# Altium schematic sheet DCDC_12V.SchDoc(DCDC_12V)
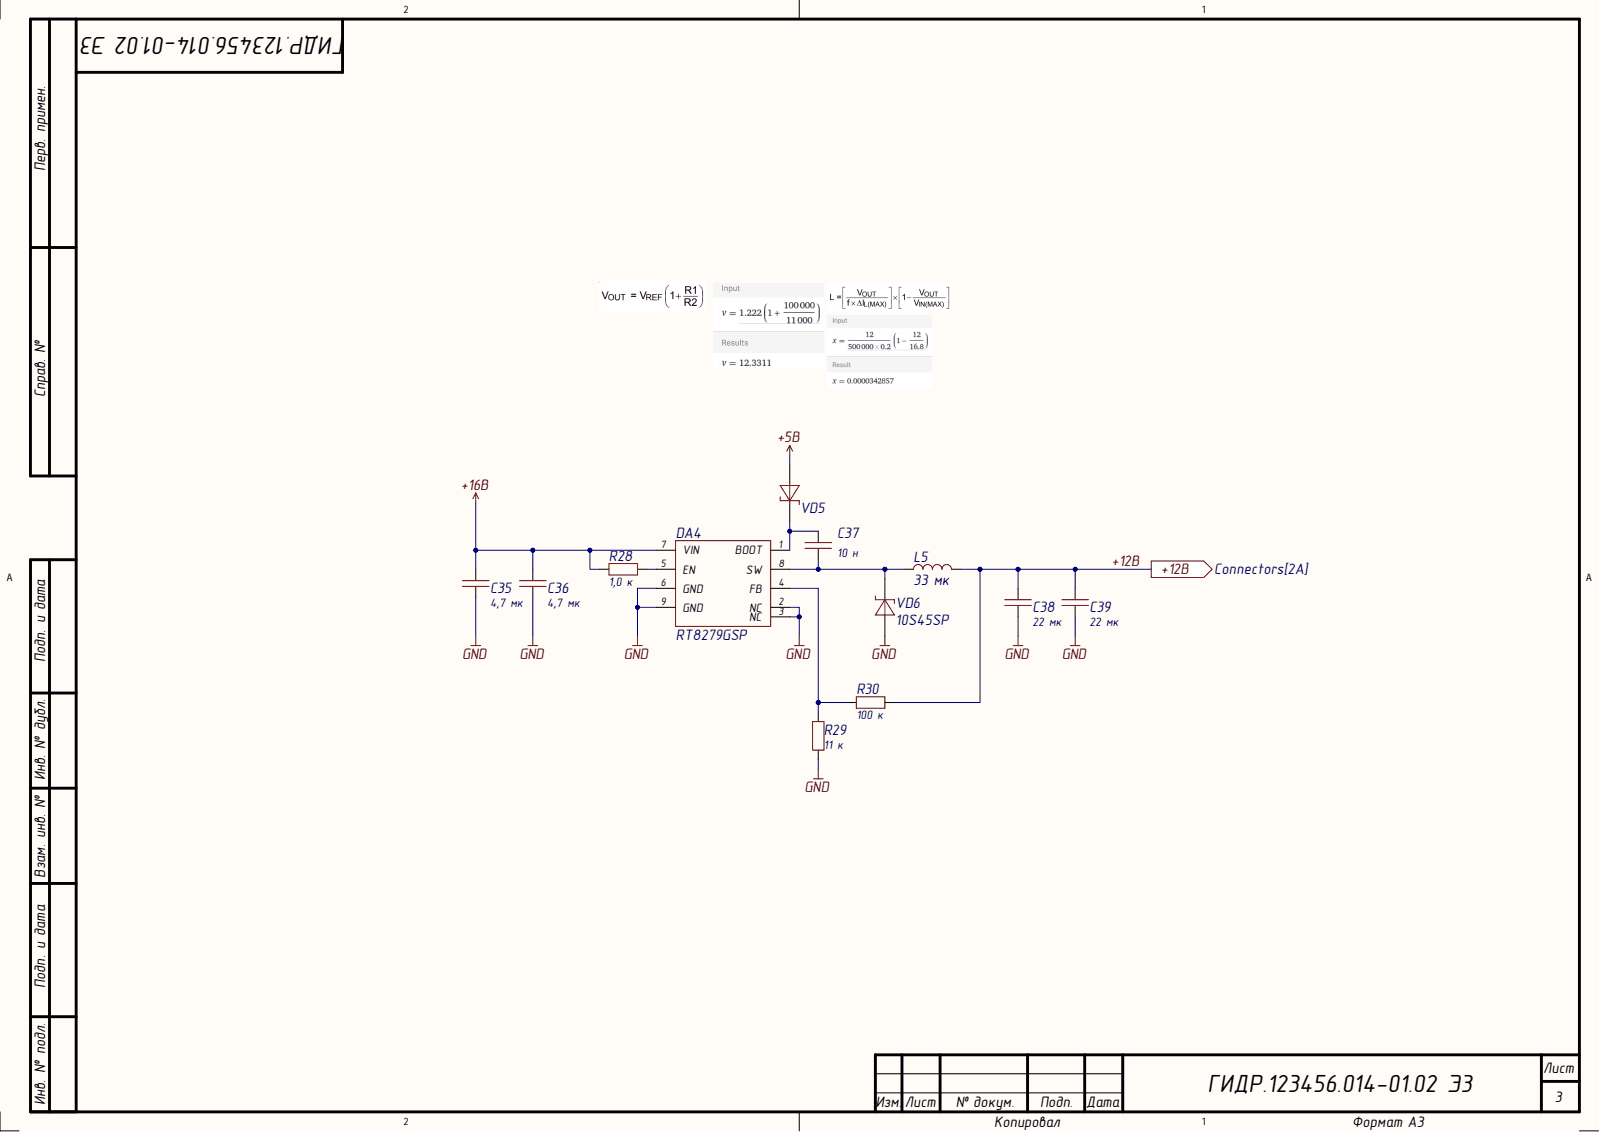
[diagram p0: DCDC_12V.SchDoc]

## Parts
C35  value 4,7 мк  [1206C]  (hidden comment: Capacitor)
C36  value 4,7 мк  [1206C]  (hidden comment: Capacitor)
C37  value 10 н  [0603C]  (hidden comment: Capacitor)
C38  value 22 мк  [1210C]  (hidden comment: Capacitor)
C39  value 22 мк  [1210C]  (hidden comment: Capacitor)
DA4  RT8279GSP  [8SOP(Exposed_Pad)]
L5  value 33 мк  [IHLP-4040]  (hidden comment: IHLP4040DZER330M11)
R28  value 1,0 к  [0603R]  (hidden comment: Resistor)
R29  value 11 к  [0603R]  (hidden comment: Resistor)
R30  value 100 к  [0603R]  (hidden comment: Resistor)
VD5  BAT54WS  [SOD-323]  (value not drawn)
VD6  10S45SP  [TO-277]

## Ports
+12В

## Nets
+5В: VD5.1
+16В: C35.2 C36.2 DA4.7(VIN) R28.2
GND: C35.1 C36.1 C38.1 C39.1 DA4.2(NC) DA4.3(NC) DA4.6(GND) DA4.9(GND) R29.1 VD6.1
+12В: C38.2 C39.2 L5.2 R30.2 ; port +12В
NetC37_1: C37.1 DA4.8(SW) L5.1 VD6.2
NetC37_2: C37.2 DA4.1(BOOT) VD5.2
NetDA4_4: DA4.4(FB) R29.2 R30.1
NetDA4_5: DA4.5(EN) R28.1

## Library data of the parts
C35 = Capacitor_4,7u_10_50V_X7R_1206C: Конденсатор; Capacity (nF): 4700; Database Table Name: Capacitors_ceramic$; Dielectric: X7R; Library Path2: Schematic\Capacitor.SchLib; Tolerance: 0,1; Value: 4,7 мк; Voltage (V): 50; Component Kind: Standard; Library Name: Hydrolib.DbLib; Part Number: Capacitor_4,7u_10_50V_X7R_1206C
C36 = Capacitor_4,7u_10_50V_X7R_1206C: Конденсатор; Capacity (nF): 4700; Database Table Name: Capacitors_ceramic$; Dielectric: X7R; Library Path2: Schematic\Capacitor.SchLib; Tolerance: 0,1; Voltage (V): 50; Component Kind: Standard; Library Name: Hydrolib.DbLib; Part Number: Capacitor_4,7u_10_50V_X7R_1206C
C37 = Capacitor_10n_10_50V_X7R_0603C: Конденсатор; Capacity (nF): 10; Database Table Name: Capacitors_ceramic$; Dielectric: X7R; Library Path2: Schematic\Capacitor.SchLib; Tolerance: 0,1; Voltage (V): 50; Component Kind: Standard; Library Name: Hydrolib.DbLib; Part Number: Capacitor_10n_10_50V_X7R_0603C
C38 = Capacitor_22u_10_25V_X7R_1210C: Конденсатор; Capacity (nF): 22000; Database Table Name: Capacitors_ceramic$; Dielectric: X7R; Library Path2: Schematic\Capacitor.SchLib; Tolerance: 0,1; Value: 22 мк; Voltage (V): 25; Component Kind: Standard; Library Name: Hydrolib.DbLib; Part Number: Capacitor_22u_10_25V_X7R_1210C
C39 = Capacitor_22u_10_25V_X7R_1210C: Конденсатор; Capacity (nF): 22000; Database Table Name: Capacitors_ceramic$; Dielectric: X7R; Library Path2: Schematic\Capacitor.SchLib; Tolerance: 0,1; Voltage (V): 25; Component Kind: Standard; Library Name: Hydrolib.DbLib; Part Number: Capacitor_22u_10_25V_X7R_1210C
DA4 = RT8279GSP: Преобразователь постоянного тока понижающий подстраиваемый выход 5А; Database Table Name: Chips$; Component Kind: Standard; Library Name: Hydrolib.DbLib; Part Number: RT8279GSP
L5 = IHLP4040DZER330M11: ЧИП-индуктивность экранированная 33мкГн ±20% 4,4A 94,5мОм 4040; Current (A): 4,4; Database Table Name: Inductance$; Inductance (μH): 33; Component Kind: Standard; Library Name: Hydrolib.DbLib; Part Number: IHLP4040DZER330M11
R28 = Resistor_1.0k_1_0603: Резистор номиналом 1,0 кОм и точностью 1%; Database Table Name: Resistors$; Component Kind: Standard; Library Name: Hydrolib.DbLib; Part Number: Resistor_1.0k_1_0603; Tolerance: 1%
R29 = Resistor_11k_1_0603: Резистор номиналом 11 кОм и точностью 1%; Database Table Name: Resistors$; Component Kind: Standard; Library Name: Hydrolib.DbLib; Part Number: Resistor_11k_1_0603; Tolerance: 1%
R30 = Resistor_100k_1_0603: Резистор номиналом 100 кОм и точностью 1%; Database Table Name: Resistors$; Component Kind: Standard; Library Name: Hydrolib.DbLib; Part Number: Resistor_100k_1_0603; Tolerance: 1%
VD5 = BAT54WS: Диод шоттки на 30 В, ном. ток 0.2 А; Current (A): 0.2; Database Table Name: Schottky$; Voltage nominal (V): 30; Component Kind: Standard; Library Name: Hydrolib.DbLib; Part Number: BAT54WS
VD6 = 10S45SP: Диод шоттки на 45 В, ном. ток 10 А; Current (A): 10; Database Table Name: Schottky$; Voltage nominal (V): 45; Component Kind: Standard; Library Name: Hydrolib.DbLib; Part Number: 10S45SP
